# Altium schematic sheet Sheet1_Overview.SchDoc
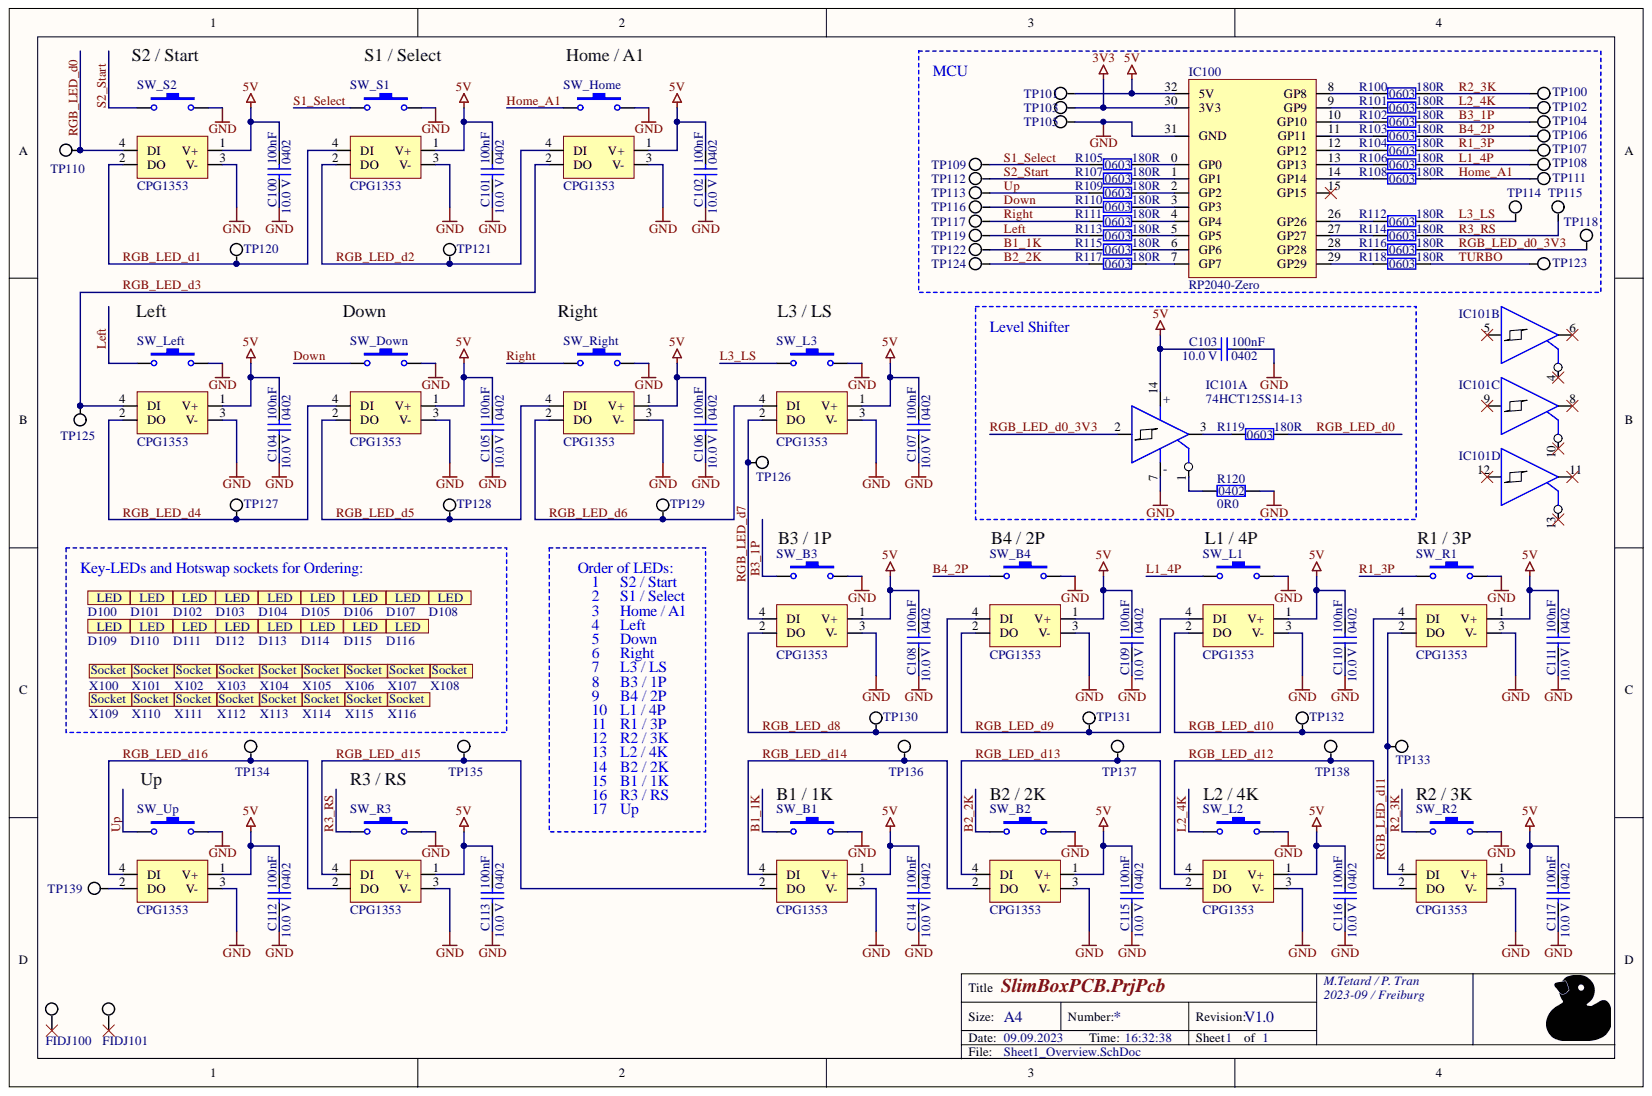
[diagram p0: Sheet1_Overview.SchDoc]

## Parts
C100  Capacitance: 100nF  Voltage: 10.0 V  [0402]  (hidden comment: C 100nF 0402 10V)
C101  Capacitance: 100nF  Voltage: 10.0 V  [0402]  (hidden comment: C 100nF 0402 10V)
C102  Capacitance: 100nF  Voltage: 10.0 V  [0402]  (hidden comment: C 100nF 0402 10V)
C103  Capacitance: 100nF  Voltage: 10.0 V  [0402]  (hidden comment: C 100nF 0402 10V)
C104  Capacitance: 100nF  Voltage: 10.0 V  [0402]  (hidden comment: C 100nF 0402 10V)
C105  Capacitance: 100nF  Voltage: 10.0 V  [0402]  (hidden comment: C 100nF 0402 10V)
C106  Capacitance: 100nF  Voltage: 10.0 V  [0402]  (hidden comment: C 100nF 0402 10V)
C107  Capacitance: 100nF  Voltage: 10.0 V  [0402]  (hidden comment: C 100nF 0402 10V)
C108  Capacitance: 100nF  Voltage: 10.0 V  [0402]  (hidden comment: C 100nF 0402 10V)
C109  Capacitance: 100nF  Voltage: 10.0 V  [0402]  (hidden comment: C 100nF 0402 10V)
C110  Capacitance: 100nF  Voltage: 10.0 V  [0402]  (hidden comment: C 100nF 0402 10V)
C111  Capacitance: 100nF  Voltage: 10.0 V  [0402]  (hidden comment: C 100nF 0402 10V)
C112  Capacitance: 100nF  Voltage: 10.0 V  [0402]  (hidden comment: C 100nF 0402 10V)
C113  Capacitance: 100nF  Voltage: 10.0 V  [0402]  (hidden comment: C 100nF 0402 10V)
C114  Capacitance: 100nF  Voltage: 10.0 V  [0402]  (hidden comment: C 100nF 0402 10V)
C115  Capacitance: 100nF  Voltage: 10.0 V  [0402]  (hidden comment: C 100nF 0402 10V)
C116  Capacitance: 100nF  Voltage: 10.0 V  [0402]  (hidden comment: C 100nF 0402 10V)
C117  Capacitance: 100nF  Voltage: 10.0 V  [0402]  (hidden comment: C 100nF 0402 10V)
D100  LED RGB  (value not drawn)
D101  LED RGB  (value not drawn)
D102  LED RGB  (value not drawn)
D103  LED RGB  (value not drawn)
D104  LED RGB  (value not drawn)
D105  LED RGB  (value not drawn)
D106  LED RGB  (value not drawn)
D107  LED RGB  (value not drawn)
D108  LED RGB  (value not drawn)
D109  LED RGB  (value not drawn)
D110  LED RGB  (value not drawn)
D111  LED RGB  (value not drawn)
D112  LED RGB  (value not drawn)
D113  LED RGB  (value not drawn)
D114  LED RGB  (value not drawn)
D115  LED RGB  (value not drawn)
D116  LED RGB  (value not drawn)
FIDJ100  Fiducial  [FIDJ_1mm]  (value not drawn)
FIDJ101  Fiducial  [FIDJ_1mm]  (value not drawn)
IC100  RP2040-Zero  [IC_RP2040-Zero]
IC101  74HCT125S14-13  [SOIC-14]  (parts A,B,C,D)
R100  Resistance: 180R  [0603]  (hidden comment: R 180R 0603)
R101  Resistance: 180R  [0603]  (hidden comment: R 180R 0603)
R102  Resistance: 180R  [0603]  (hidden comment: R 180R 0603)
R103  Resistance: 180R  [0603]  (hidden comment: R 180R 0603)
R104  Resistance: 180R  [0603]  (hidden comment: R 180R 0603)
R105  Resistance: 180R  [0603]  (hidden comment: R 180R 0603)
R106  Resistance: 180R  [0603]  (hidden comment: R 180R 0603)
R107  Resistance: 180R  [0603]  (hidden comment: R 180R 0603)
R108  Resistance: 180R  [0603]  (hidden comment: R 180R 0603)
R109  Resistance: 180R  [0603]  (hidden comment: R 180R 0603)
R110  Resistance: 180R  [0603]  (hidden comment: R 180R 0603)
R111  Resistance: 180R  [0603]  (hidden comment: R 180R 0603)
R112  Resistance: 180R  [0603]  (hidden comment: R 180R 0603)
R113  Resistance: 180R  [0603]  (hidden comment: R 180R 0603)
R114  Resistance: 180R  [0603]  (hidden comment: R 180R 0603)
R115  Resistance: 180R  [0603]  (hidden comment: R 180R 0603)
R116  Resistance: 180R  [0603]  (hidden comment: R 180R 0603)
R117  Resistance: 180R  [0603]  (hidden comment: R 180R 0603)
R118  Resistance: 180R  [0603]  (hidden comment: R 180R 0603)
R119  Resistance: 180R  [0603]  (hidden comment: R 180R 0603)
R120  Resistance: 0R0  [0402]  (hidden comment: R 0R0 0402)
SW_B1  CPG1353  [SW_Kailh_CPG1353]
SW_B2  CPG1353  [SW_Kailh_CPG1353]
SW_B3  CPG1353  [SW_Kailh_CPG1353]
SW_B4  CPG1353  [SW_Kailh_CPG1353]
SW_Down  CPG1353  [SW_Kailh_CPG1353]
SW_Home  CPG1353  [SW_Kailh_CPG1353]
SW_L1  CPG1353  [SW_Kailh_CPG1353]
SW_L2  CPG1353  [SW_Kailh_CPG1353]
SW_L3  CPG1353  [SW_Kailh_CPG1353]
SW_Left  CPG1353  [SW_Kailh_CPG1353]
SW_R1  CPG1353  [SW_Kailh_CPG1353]
SW_R2  CPG1353  [SW_Kailh_CPG1353]
SW_R3  CPG1353  [SW_Kailh_CPG1353]
SW_Right  CPG1353  [SW_Kailh_CPG1353]
SW_S1  CPG1353  [SW_Kailh_CPG1353]
SW_S2  CPG1353  [SW_Kailh_CPG1353]
SW_Up  CPG1353  [SW_Kailh_CPG1353]
TP100  TP  [TP_1mm]
TP101  TP  [TP_1mm]
TP102  TP  [TP_1mm]
TP103  TP  [TP_1mm]
TP104  TP  [TP_1mm]
TP105  TP  [TP_1mm]
TP106  TP  [TP_1mm]
TP107  TP  [TP_1mm]
TP108  TP  [TP_1mm]
TP109  TP  [TP_1mm]
TP110  TP  [TP_1mm]
TP111  TP  [TP_1mm]
TP112  TP  [TP_1mm]
TP113  TP  [TP_1mm]
TP114  TP  [TP_1mm]
TP115  TP  [TP_1mm]
TP116  TP  [TP_1mm]
TP117  TP  [TP_1mm]
TP118  TP  [TP_1mm]
TP119  TP  [TP_1mm]
TP120  TP  [TP_1mm]
TP121  TP  [TP_1mm]
TP122  TP  [TP_1mm]
TP123  TP  [TP_1mm]
TP124  TP  [TP_1mm]
TP125  TP  [TP_1mm]
TP126  TP  [TP_1mm]
TP127  TP  [TP_1mm]
TP128  TP  [TP_1mm]
TP129  TP  [TP_1mm]
TP130  TP  [TP_1mm]
TP131  TP  [TP_1mm]
TP132  TP  [TP_1mm]
TP133  TP  [TP_1mm]
TP134  TP  [TP_1mm]
TP135  TP  [TP_1mm]
TP136  TP  [TP_1mm]
TP137  TP  [TP_1mm]
TP138  TP  [TP_1mm]
TP139  TP  [TP_1mm]
X100  Socket
X101  Socket
X102  Socket
X103  Socket
X104  Socket
X105  Socket
X106  Socket
X107  Socket
X108  Socket
X109  Socket
X110  Socket
X111  Socket
X112  Socket
X113  Socket
X114  Socket
X115  Socket
X116  Socket

## Nets
3V3: IC100.30(3V3) TP103.1
5V: C100.2 C101.2 C102.2 C103.1 C104.2 C105.2 C106.2 C107.2 C108.2 C109.2 C110.2 C111.2 C112.2 C113.2 C114.2 C115.2 C116.2 C117.2 IC100.32(5V) IC101.14 SW_B1.1(V+) SW_B2.1(V+) SW_B3.1(V+) SW_B4.1(V+) SW_Down.1(V+) SW_Home.1(V+) SW_L1.1(V+) SW_L2.1(V+) SW_L3.1(V+) SW_Left.1(V+) SW_R1.1(V+) SW_R2.1(V+) SW_R3.1(V+) SW_Right.1(V+) SW_S1.1(V+) SW_S2.1(V+) SW_Up.1(V+) TP101.1
GND: C100.1 C101.1 C102.1 C103.2 C104.1 C105.1 C106.1 C107.1 C108.1 C109.1 C110.1 C111.1 C112.1 C113.1 C114.1 C115.1 C116.1 C117.1 IC100.31(GND) IC101.7 R120.1 SW_B1.3(V-) SW_B1.B SW_B2.3(V-) SW_B2.B SW_B3.3(V-) SW_B3.B SW_B4.3(V-) SW_B4.B SW_Down.3(V-) SW_Down.B SW_Home.3(V-) SW_Home.B SW_L1.3(V-) SW_L1.B SW_L2.3(V-) SW_L2.B SW_L3.3(V-) SW_L3.B SW_Left.3(V-) SW_Left.B SW_R1.3(V-) SW_R1.B SW_R2.3(V-) SW_R2.B SW_R3.3(V-) SW_R3.B SW_Right.3(V-) SW_Right.B SW_S1.3(V-) SW_S1.B SW_S2.3(V-) SW_S2.B SW_Up.3(V-) SW_Up.B TP105.1
B1_1K: R115.1 SW_B1.A TP122.1
B2_2K: R117.1 SW_B2.A TP124.1
B3_1P: R102.2 SW_B3.A TP104.1
B4_2P: R103.2 SW_B4.A TP106.1
DOWN: R110.1 SW_Down.A TP116.1
HOME_A1: R108.2 SW_Home.A TP111.1
L1_4P: R106.2 SW_L1.A TP108.1
L2_4K: R101.2 SW_L2.A TP102.1
L3_LS: R112.2 SW_L3.A TP114.1
LEFT: R113.1 SW_Left.A TP119.1
R1_3P: R104.2 SW_R1.A TP107.1
R2_3K: R100.2 SW_R2.A TP100.1
R3_RS: R114.2 SW_R3.A TP115.1
RGB_LED_D0: R119.2 SW_S2.4(DI) TP110.1
RGB_LED_D0_3V3: IC101.2 R116.2 TP118.1
RGB_LED_D1: SW_S1.4(DI) SW_S2.2(DO) TP120.1
RGB_LED_D2: SW_Home.4(DI) SW_S1.2(DO) TP121.1
RGB_LED_D3: SW_Home.2(DO) SW_Left.4(DI) TP125.1
RGB_LED_D4: SW_Down.4(DI) SW_Left.2(DO) TP127.1
RGB_LED_D5: SW_Down.2(DO) SW_Right.4(DI) TP128.1
RGB_LED_D6: SW_L3.4(DI) SW_Right.2(DO) TP129.1
RGB_LED_D7: SW_B3.4(DI) SW_L3.2(DO) TP126.1
RGB_LED_D8: SW_B3.2(DO) SW_B4.4(DI) TP130.1
RGB_LED_D9: SW_B4.2(DO) SW_L1.4(DI) TP131.1
RGB_LED_D10: SW_L1.2(DO) SW_R1.4(DI) TP132.1
RGB_LED_D11: SW_R1.2(DO) SW_R2.4(DI) TP133.1
RGB_LED_D12: SW_L2.4(DI) SW_R2.2(DO) TP138.1
RGB_LED_D13: SW_B2.4(DI) SW_L2.2(DO) TP137.1
RGB_LED_D14: SW_B1.4(DI) SW_B2.2(DO) TP136.1
RGB_LED_D15: SW_B1.2(DO) SW_R3.4(DI) TP135.1
RGB_LED_D16: SW_R3.2(DO) SW_Up.4(DI) TP134.1
RIGHT: R111.1 SW_Right.A TP117.1
S1_SELECT: R105.1 SW_S1.A TP109.1
S2_START: R107.1 SW_S2.A TP112.1
TURBO: R118.2 TP123.1
UP: R109.1 SW_Up.A TP113.1
NetIC100_0: IC100.0(GP0) R105.2
NetIC100_1: IC100.1(GP1) R107.2
NetIC100_2: IC100.2(GP2) R109.2
NetIC100_3: IC100.3(GP3) R110.2
NetIC100_4: IC100.4(GP4) R111.2
NetIC100_5: IC100.5(GP5) R113.2
NetIC100_6: IC100.6(GP6) R115.2
NetIC100_7: IC100.7(GP7) R117.2
NetIC100_8: IC100.8(GP8) R100.1
NetIC100_9: IC100.9(GP9) R101.1
NetIC100_10: IC100.10(GP10) R102.1
NetIC100_11: IC100.11(GP11) R103.1
NetIC100_12: IC100.12(GP12) R104.1
NetIC100_13: IC100.13(GP13) R106.1
NetIC100_14: IC100.14(GP14) R108.1
NetIC100_26: IC100.26(GP26) R112.1
NetIC100_27: IC100.27(GP27) R114.1
NetIC100_28: IC100.28(GP28) R116.1
NetIC100_29: IC100.29(GP29) R118.1
NetIC101_1: IC101.1 R120.2
NetIC101_3: IC101.3 R119.1
NetSW_Up_2: SW_Up.2(DO) TP139.1

Not connected: FIDJ100 1; FIDJ101 1; IC100 15(GP15); IC101 4 5 6 8 9 10 11 12 13

## Library data of the parts
C100-C117 = CAP_100nF_10V_0402: CAP CER 0.1UF 10V X5R 0402; Manufacturer: Samsung Electro-Mechanics; Manufacturer Part Number: CL05A104MP5NNNC; Type: Capacitor; Component Kind: Standard; Library Name: CS_Part_Library.IntLib; Supplier 1: Digi-Key; Supplier Part Number 1: 1276-1443-1-ND
D100-D116 = SW_CPG1353_LED: LED for SW_CPG1353; Manufacturer: Opsco; Manufacturer Part Number: SK6812Mini-E; Type: other, switch; Component Kind: Standard; Library Name: Symbols.SchLib
FIDJ100 FIDJ101 = FIDJ_1mm: Fiducal 1mm; Component Kind: Net Tie; Library Name: CS_Part_Library.IntLib
IC100 = IC_RP2040-Zero: Waveshare RP2040-Zero Module; Component Kind: Standard; Library Name: CS_Part_Library.IntLib; Manufacturer: Waveshare; Manufacturer Part Number: RP2040-Zero; Type: other, module
IC101 = IC_Logic_1BUF_4CH: IC BUFFER NON-INVERT 5.5V 14SO; Manufacturer: Diodes Incorporated; Component Kind: Standard; Library Name: CS_Part_Library.IntLib; Manufacturer Part Number: 74HCT125S14-13; Supplier 1: Digi-Key; Supplier Part Number 1: 74HCT125S14-13-ND; Type: IC
R100-R119 = R_180R_0603: RES 180 OHM 1% 1/10W 0603; Manufacturer: Stackpole Electronics Inc; Manufacturer Part Number: RMCF0603FT180R; Component Kind: Standard; Library Name: CS_Part_Library.IntLib; Supplier 1: Digi-Key; Supplier Part Number 1: RMCF0603FT180RCT-ND; Type: Resistor
R120 = R_0R0_0402: RES 0 OHM JUMPER 1/16W 0402; Manufacturer: Stackpole Electronics Inc; Manufacturer Part Number: RMCF0402ZT0R00; Component Kind: Standard; Library Name: CS_Part_Library.IntLib; Supplier 1: Digi-Key; Supplier Part Number 1: RMCF0402ZT0R00CT-ND; Type: Resistor
SW_B1-SW_B4 SW_Down SW_Home SW_L1-SW_L3 SW_Left SW_R1-SW_R3 SW_Right SW_S1 SW_S2 SW_Up = SW_CPG1353_HS_LED: Kailh Choc low profile switches V2 (CPG1353) /w Hotswap, LED; Component Kind: Standard; Library Name: CS_Part_Library.IntLib; Manufacturer: Kailh; Manufacturer Part Number: CPG1353; Type: other, switch
TP100-TP139 = TP_1mm: Test Point 1mm; Component Kind: Net Tie; Library Name: CS_Part_Library.IntLib
X100-X116 = SW_CPG1353_socket: Socket for SW_CPG1353; Manufacturer: Kailh; Manufacturer Part Number: PG1350; Component Kind: Standard; Library Name: Symbols.SchLib; Type: other
